# Altium schematic sheet board_sheet6.SchDoc
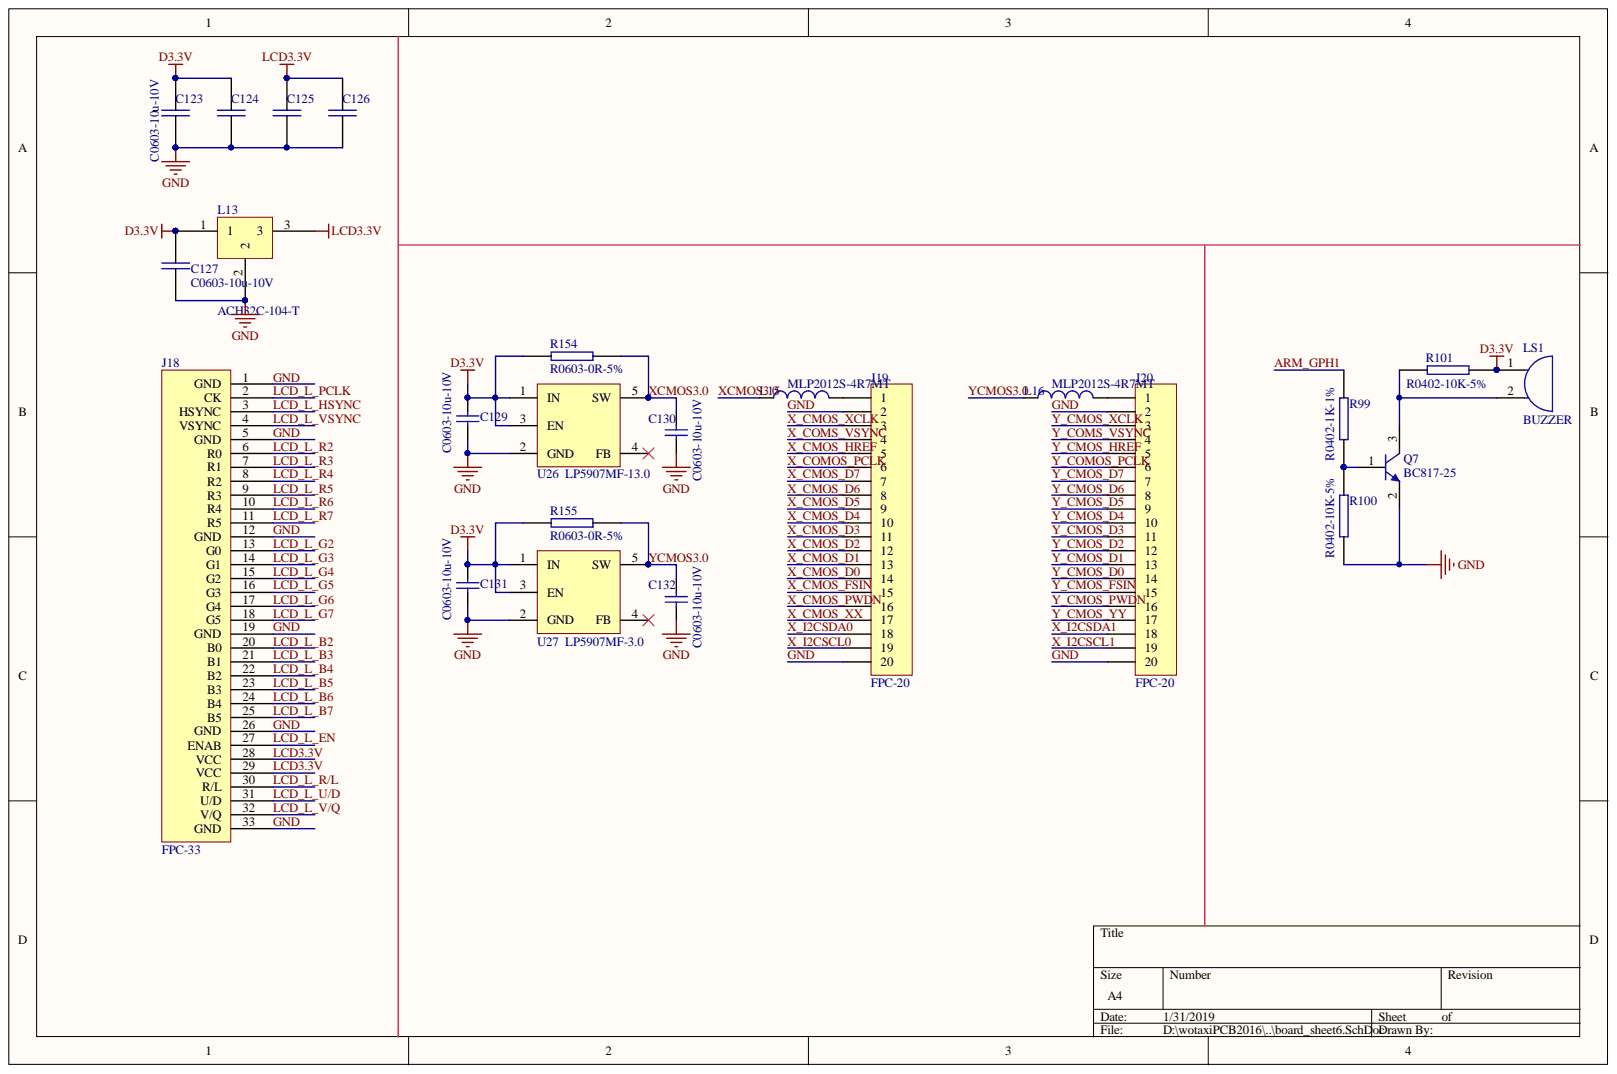
[diagram p0: board_sheet6.SchDoc]

## Parts
C123  C0603-10u-10V  [0603]
C124  C0603-10u-10V  [0603]
C125  C0603-10u-10V  [0603]
C126  C0603-10u-10V  [0603]
C127  C0603-10u-10V  [0603]
C129  C0603-10u-10V  [C_0603]
C130  C0603-10u-10V  [C_0603]
C131  C0603-10u-10V  [C_0603]
C132  C0603-10u-10V  [C_0603]
J18  FPC-33  [FPC0.5-SMT-33P]
J19  FPC-20  [FPC0.5-SMT-20P]
J20  FPC-20  [FPC0.5-SMT-20P]
L13  ACH32C-104-T  [ACH32C]
L15  MLP2012S-4R7MT  [0805]
L16  MLP2012S-4R7MT  [0805]
LS1  BUZZER  [BUZZER]
Q7  BC817-25  [SO-G3/C2.5]
R99  R0402-1K-1%  [0402]
R100  R0402-10K-5%  [0402]
R101  R0402-10K-5%  [0402]
R154  R0603-0R-5%  [0603]
R155  R0603-0R-5%  [0603]
U26  LP5907MF-13.0  [SOT5-23]
U27  LP5907MF-3.0  [SOT5-23]

## Nets
D3.3V: C123.1 C124.1 C127.2 C129.2 C131.2 L13.1 LS1.1 R101.1 R154.1 R155.1 U26.1(IN) U26.3(EN) U27.1(IN) U27.3(EN)
ARM_GPH1: R99.1
GND: C123.2 C124.2 C125.2 C126.2 C127.1 C129.1 C130.1 C131.1 C132.1 J18.1(GND) J18.5(GND) J18.12(GND) J18.19(GND) J18.26(GND) J18.33(GND) J19.2 J19.20 J20.2 J20.20 L13.2 Q7.2 R100.1 U26.2(GND) U27.2(GND)
LCD3.3V: C125.1 C126.1 J18.28(VCC) J18.29(VCC) L13.3
LCD_L_B2: J18.20(B0)
LCD_L_B3: J18.21(B1)
LCD_L_B4: J18.22(B2)
LCD_L_B5: J18.23(B3)
LCD_L_B6: J18.24(B4)
LCD_L_B7: J18.25(B5)
LCD_L_EN: J18.27(ENAB)
LCD_L_G2: J18.13(G0)
LCD_L_G3: J18.14(G1)
LCD_L_G4: J18.15(G2)
LCD_L_G5: J18.16(G3)
LCD_L_G6: J18.17(G4)
LCD_L_G7: J18.18(G5)
LCD_L_HSYNC: J18.3(HSYNC)
LCD_L_PCLK: J18.2(CK)
LCD_L_R2: J18.6(R0)
LCD_L_R3: J18.7(R1)
LCD_L_R4: J18.8(R2)
LCD_L_R5: J18.9(R3)
LCD_L_R6: J18.10(R4)
LCD_L_R7: J18.11(R5)
LCD_L_R/L: J18.30(R/L)
LCD_L_U/D: J18.31(U/D)
LCD_L_V/Q: J18.32(V/Q)
LCD_L_VSYNC: J18.4(VSYNC)
X_CMOS_D0: J19.14
X_CMOS_D1: J19.13
X_CMOS_D2: J19.12
X_CMOS_D3: J19.11
X_CMOS_D4: J19.10
X_CMOS_D5: J19.9
X_CMOS_D6: J19.8
X_CMOS_D7: J19.7
X_CMOS_FSIN: J19.15
X_CMOS_HREF: J19.5
X_CMOS_PWDN: J19.16
X_CMOS_XCLK: J19.3
X_CMOS_XX: J19.17
X_COMOS_PCLK: J19.6
X_COMS_VSYNC: J19.4
X_I2CSCL0: J19.19
X_I2CSCL1: J20.19
X_I2CSDA0: J19.18
X_I2CSDA1: J20.18
XCMOS3.0: C130.2 L15.1 R154.2 U26.5(SW)
Y_CMOS_D0: J20.14
Y_CMOS_D1: J20.13
Y_CMOS_D2: J20.12
Y_CMOS_D3: J20.11
Y_CMOS_D4: J20.10
Y_CMOS_D5: J20.9
Y_CMOS_D6: J20.8
Y_CMOS_D7: J20.7
Y_CMOS_FSIN: J20.15
Y_CMOS_HREF: J20.5
Y_CMOS_PWDN: J20.16
Y_CMOS_XCLK: J20.3
Y_CMOS_YY: J20.17
Y_COMOS_PCLK: J20.6
Y_COMS_VSYNC: J20.4
YCMOS3.0: C132.2 L16.1 R155.2 U27.5(SW)
NetJ19_1: J19.1 L15.2
NetJ20_1: J20.1 L16.2
NetLS1_2: LS1.2 Q7.3 R101.2
NetQ7_1: Q7.1 R99.2 R100.2

Not connected: U26 4(FB); U27 4(FB)

## Library data of the parts
C123-C127 = CAP: Component Kind: Standard
  C127: Library Name: KL300-POWER.SCHLIB
  C123-C126: Library Name: KL300-CONTROLER.SCHLIB
C129-C132 = C1210: Cermanic Chip Capacitor - Standard; Manufacturer: KEMET Electronics; Class: Passive; Value: 10u; Component Kind: Standard; Published: 15/04/2002; Signal Integrity: C1210; Simulation: CAP; SubClass: Capacitor; Library Name: KL300-CONTROLER.SCHLIB; Publisher: Altium Hobart Technology Centre
L13 = ACH32C: Component Kind: Standard; Library Name: KL300-POWER.SCHLIB
L15 L16 = INDUCTOR: Component Kind: Standard; Library Name: KL300-CONTROLER.SCHLIB
R99-R101 R154 R155 = RES: Component Kind: Standard; Library Name: KL300-CONTROLER.SCHLIB
U26 U27 = LM3671MF-1.2: Component Kind: Standard; Library Name: KL300-CONTROLER.SCHLIB
J18 = FPC-33: Component Kind: Standard; Library Name: KL300-CONTROLER.SCHLIB
J19 J20 = FPC-20: Component Kind: Standard; Library Name: KL300-CONTROLER.SCHLIB
LS1 = BUZZER: Component Kind: Standard; Library Name: KL300-CONTROLER.SCHLIB
Q7 = BC817-25: Component Kind: Standard; Library Name: KL300-CONTROLER.SCHLIB
